# Altium schematic sheet Microbit Programming Interface.SchDoc
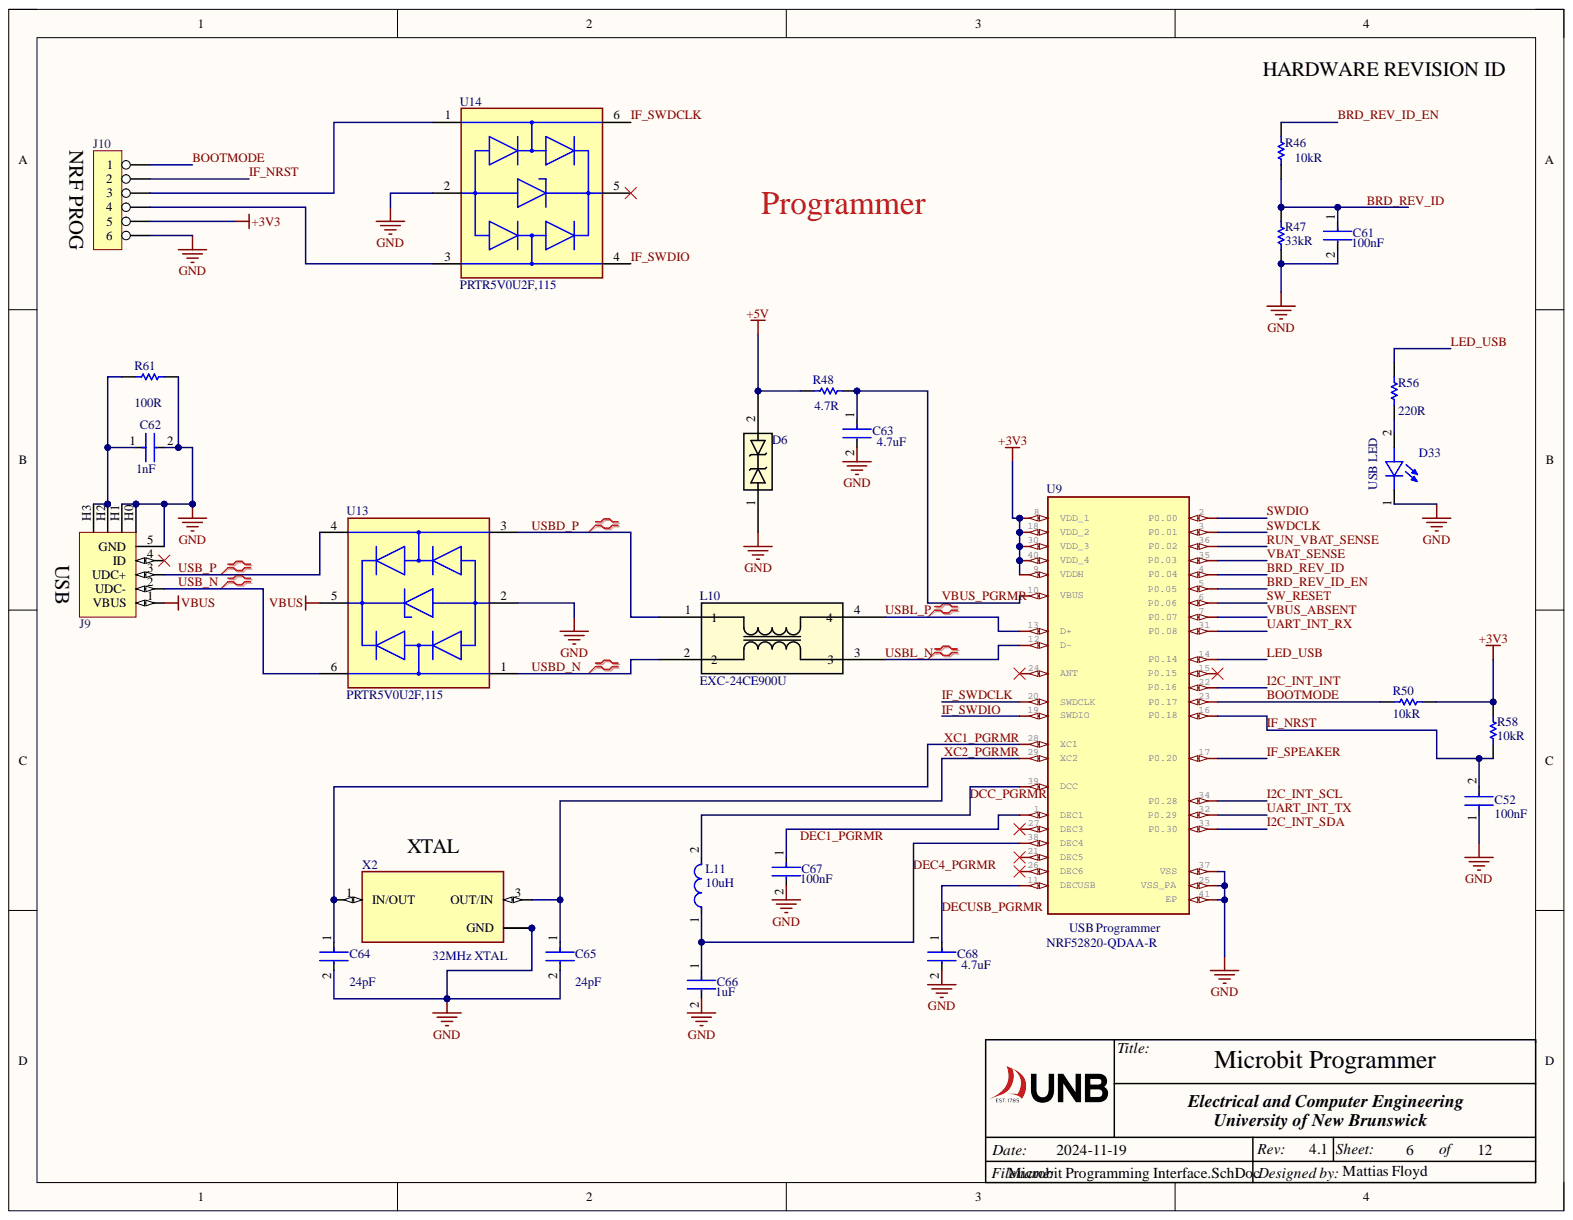
[diagram p0: Microbit Programming Interface.SchDoc]

## Parts
C52  Capacitance: 100nF  [0402 CAP]  (hidden comment: CAP GRM155R61H104KE14D)
C61  Capacitance: 100nF  [0402 CAP]  (hidden comment: CAP GRM155R61H104KE14D)
C62  Capacitance: 1nF  [0402 CAP]  (hidden comment: CAP CL05C102JB5NNNC)
C63  Capacitance: 4.7uF  [0402 CAP]  (hidden comment: CAP C1005X5R1A475K050BC)
C64  Capacitance: 24pF  [0402 CAP]  (hidden comment: CAP CC0402JRNPO9BN240)
C65  Capacitance: 24pF  [0402 CAP]  (hidden comment: CAP CC0402JRNPO9BN240)
C66  Capacitance: 1uF  [0402 CAP]  (hidden comment: CAP CC0402KRX5R5BB105)
C67  Capacitance: 100nF  [0402 CAP]  (hidden comment: CAP GRM155R61H104KE14D)
C68  Capacitance: 4.7uF  [0402 CAP]  (hidden comment: CAP C1005X5R1A475K050BC)
D6  DIODE D5V0H1B2LP-7B  [X1-DFN1006-2_DIO-L]  (value not drawn)
D33  USB LED  [0603 LED]
J9  CON_USB_MICRO_AB_MOLEX  [CON_USB_MICRO_AB_MOLEX]  (value not drawn)
J10  CON_HDR_6X1_0.1IN  [CON_HDR_6X1]  (value not drawn)
L10  EXC-24CE900U  [EXC24CE_PAN]
L11  10uH  [FP-LQM18FN_00-IPC_A]
R46  Resistance: 10kR  [0402 RES]  (hidden comment: RES MC00625W0402110K)
R47  Resistance: 33kR  [0402 RES]  (hidden comment: RES RC0402JR-0733KL)
R48  Resistance: 4.7R  [0402 RES]  (hidden comment: RES RC0402FR-074R7L)
R50  Resistance: 10kR  [0402 RES]  (hidden comment: RES MC00625W0402110K)
R56  Resistance: 220R  [0402 RES]  (hidden comment: RES ERJ-2RKF2200X)
R58  Resistance: 10kR  [0402 RES]  (hidden comment: RES MC00625W0402110K)
R61  RES RC0805JR-07100RL  [0805 RESISTOR]  (value not drawn)
U9  NRF52820-QDAA-R  [QFN40P500X500X90-41N]
U13  PRTR5V0U2F,115  [FP-SOT886-MFG]
U14  PRTR5V0U2F,115  [FP-SOT886-MFG]
X2  32MHz XTAL  [XTAL_ECS-320-16-33B-CKM-TR]

## Nets
+3V3: J10.5 R50.1 R58.1 U9.8(VDD_1) U9.9(VDDH) U9.18(VDD_2) U9.30(VDD_3) U9.40(VDD_4)
+5V: D6.2 R48.1
GND: C52.1 C61.2 C62.2 C63.2 C64.2 C65.2 C66.2 C67.2 C68.2 D6.1 D33.1 J9.5(GND) J9.H0 J9.H1 J10.6 R47.1 R61.2 U9.25(VSS_PA) U9.37(VSS) U9.41(EP) U13.2 U14.2 X2.2(GND) X2.4
VBUS: J9.1(VBUS) U13.5
BOOTMODE: J10.1 R50.2 U9.23(P0.17)
BRD_REV_ID: C61.1 R46.1 R47.2 U9.4(P0.04)
BRD_REV_ID_EN: R46.2 U9.5(P0.05)
DCC_PGRMR: L11.2 U9.39(DCC)
DEC4_PGRMR: C66.1 L11.1 U9.38(DEC4)
DECUSB_PGRMR: C68.1 U9.11(DECUSB)
I2C_INT_INT: U9.22(P0.16)
I2C_INT_SCL: U9.34(P0.28)
I2C_INT_SDA: U9.33(P0.30)
IF_NRST: C52.2 J10.2 R58.2 U9.16(P0.18)
IF_SPEAKER: U9.17(P0.20)
IF_SWDCLK: U9.20(SWDCLK) U14.6
IF_SWDIO: U9.19(SWDIO) U14.4
LED_USB: R56.2 U9.14(P0.14)
RUN_VBAT_SENSE: U9.36(P0.02)
SW_RESET: U9.6(P0.06)
SWDCLK: U9.3(P0.01)
SWDIO: U9.2(P0.00)
UART_INT_RX: U9.31(P0.08)
UART_INT_TX: U9.32(P0.29)
USB_N: J9.2(UDC-) U13.6
USB_P: J9.3(UDC+) U13.4
USBD_N: L10.2 U13.1
USBD_P: L10.1 U13.3
USBL_N: L10.3 U9.12(D-)
USBL_P: L10.4 U9.13(D+)
VBAT_SENSE: U9.35(P0.03)
VBUS_ABSENT: U9.7(P0.07)
VBUS_PGRMR: C63.1 R48.2 U9.10(VBUS)
XC1_PGRMR: C64.1 U9.28(XC1) X2.1(IN/OUT)
XC2_PGRMR: C65.1 U9.29(XC2) X2.3(OUT/IN)
NetC62_1: C62.1 J9.H2 J9.H3 R61.1
NetC67_1: C67.1 U9.1(DEC1)
NetD33_2: D33.2 R56.1
NetJ10_3: J10.3 U14.1
NetJ10_4: J10.4 U14.3
DEC1_PGRMR:

Not connected: J9 4(ID); U9 15(P0.15) 21(DEC5) 24(ANT) 26(DEC6) 27(DEC3); U14 5

## Library data of the parts
C52 C61 C67 = CAP GRM155R61H104KE14D: Ceramic Capacitor, Multilayer, Ceramic, 50V, 10% +Tol, 10% -Tol, X5R, 15% TC, 0.1uF, Surface Mount, 0402; Manufacturer: Murata; Multilayer: Yes; Capacitor Type: Ceramic; Category: Ceramic Capacitors; Component Kind: Standard; ComponentLink1Description: https://datasheet.ciiva.com:443/pdfs/VipMasterIC/IC/MURE/MURE-S-A0004362469/MURE-S-A0004364758-1.pdf?src-supplier=IHS+Markit; ComponentLink1URL: https://datasheet.ciiva.com:443/pdfs/VipMasterIC/IC/MURE/MURE-S-A0004362469/MURE-S-A0004364758-1.pdf?src-supplier=IHS+Markit; ComponentLink2Description: http://www.mouser.com/ds/2/281/c02e-2905.pdf?src-supplier=Mouser; ComponentLink2URL: http://www.mouser.com/ds/2/281/c02e-2905.pdf?src-supplier=Mouser; ComponentLink3Description: http://www.farnell.com/datasheets/2079150.pdf?src-supplier=Farnell; ComponentLink3URL: http://www.farnell.com/datasheets/2079150.pdf?src-supplier=Farnell; ComponentLink4Description: http://www.farnell.com/datasheets/2079150.pdf?src-supplier=Element14; ComponentLink4URL: http://www.farnell.com/datasheets/2079150.pdf?src-supplier=Element14; ComponentLink5Description: https://datasheet.octopart.com/GRM155R61H104KE14D-Murata-datasheet-66077066.pdf?src-supplier=Element14; ComponentLink5URL: https://datasheet.octopart.com/GRM155R61H104KE14D-Murata-datasheet-66077066.pdf?src-supplier=Element14; ComponentLink6Description: http://search.murata.co.jp/Ceramy/image/img/A01X/G101/ENG/GRM155R61H104KE14-01.pdf?src-supplier=Digikey; ComponentLink6URL: http://search.murata.co.jp/Ceramy/image/img/A01X/G101/ENG/GRM155R61H104KE14-01.pdf?src-supplier=Digikey; ComponentLink7Description: https://datasheet.octopart.com/GRM155R61H104KE14D-Murata-datasheet-22122223.pdf?src-supplier=Future+Electronics; ComponentLink7URL: https://datasheet.octopart.com/GRM155R61H104KE14D-Murata-datasheet-22122223.pdf?src-supplier=Future+Electronics; ComponentLink8Description: https://datasheet.octopart.com/GRM155R61H104KE14D-Murata-datasheet-149523029.pdf?src-supplier=Newark; ComponentLink8URL: https://datasheet.octopart.com/GRM155R61H104KE14D-Murata-datasheet-149523029.pdf?src-supplier=Newark; Dielectric Material: Ceramic; Height: 0.5mm; JESD-609 Code: e3; Length: 1mm; Manufacturer Part Number: GRM155R61H104KE14D; Max Operating Temperature: 85°C; Min Operating Temperature: -55°C; Mount: Surface Mount; Negative Tolerance: 10%; Number of Pins: 2; Package Shape: Rectangular; Package Style: SMTMeter; Packaging: Tape and Reel; Positive Tolerance: 10%; Pricing 1: 1=0.1, 10=0.031, 50=0.018, 100=0.0139, 500=0.01046, 1000=0.00821, 2500=0.00747, 5000=0.00687 (USD); Rated DC Voltage (URdc): 50V; Reach SVHC Compliant: Yes; RoHS: Yes; RoHS Compliant: Yes; Size Code: 0402; Stock 1: 1615754; Supplier 1: Digikey; Temperature Characteristics Code: X5R; Terminal Finish: Nickel; Termination: Wraparound; Width: 0.5mm; Library Name: bBoard for UNB Rev 3.SCHLIB
C62 = CAP CL05C102JB5NNNC: Ceramic Capacitor, Multilayer, Ceramic, 50V, 5% +Tol, 5% -Tol, C0G, -/+30ppm/Cel TC, 0.001uF, Surface Mount, 0402; Manufacturer: Samsung; Multilayer: Yes; Capacitor Type: Ceramic; Category: Ceramic Capacitors; Component Kind: Standard; ComponentLink1Description: https://datasheet.ciiva.com:443/pdfs/VipMasterIC/IC/SEMS/SEMS-S-A0008520254/SEMS-S-A0008520349-1.pdf?src-supplier=IHS+Markit; ComponentLink1URL: https://datasheet.ciiva.com:443/pdfs/VipMasterIC/IC/SEMS/SEMS-S-A0008520254/SEMS-S-A0008520349-1.pdf?src-supplier=IHS+Markit; ComponentLink2Description: https://datasheet.ciiva.com/25735/cl05c102jb5nnnc-25735686.pdf?src-supplier=Digikey; ComponentLink2URL: https://datasheet.ciiva.com/25735/cl05c102jb5nnnc-25735686.pdf?src-supplier=Digikey; ComponentLink3Description: https://datasheet.ciiva.com/29198/459210-da-01-en-vs-kondensator-0402-29198347.pdf?src-supplier=Conrad; ComponentLink3URL: https://datasheet.ciiva.com/29198/459210-da-01-en-vs-kondensator-0402-29198347.pdf?src-supplier=Conrad; ComponentLink4Description: https://datasheet.ciiva.com/26310/mlcc-catalogdownload2013-eng-26310343.pdf?src-supplier=Distrelec; ComponentLink4URL: https://datasheet.ciiva.com/26310/mlcc-catalogdownload2013-eng-26310343.pdf?src-supplier=Distrelec; Dielectric Material: Ceramic; Height: 0.55mm; JESD-609 Code: e3; Length: 1mm; Manufacturer Part Number: CL05C102JB5NNNC; Max Operating Temperature: 125°C; Min Operating Temperature: -55°C; Mount: Surface Mount; Negative Tolerance: 5%; Number of Pins: 2; Package Shape: Rectangular; Package Style: SMTMeter; Packaging: Tape and Reel; Positive Tolerance: 5%; Pricing 1: 1=0.11, 10=0.075, 50=0.0428, 100=0.0332, 500=0.02496, 1000=0.01961, 2500=0.01783, 5000=0.0164 (USD); Rated DC Voltage (URdc): 50V; Reach SVHC Compliant: Yes; RoHS: Yes; RoHS Compliant: Yes; Size Code: 0402; Stock 1: 129237; Supplier 1: Digikey; Supplier Part Number 1: 1276-1635-6-ND; Temperature Characteristics Code: C0G; Terminal Finish: Nickel; Termination: Wraparound; Width: 0.5mm; Library Name: bBoard for UNB Rev 4.SCHLIB
C63 C68 = CAP C1005X5R1A475K050BC: Ceramic Capacitor, Multilayer, Ceramic, 10V, 10% +Tol, 10% -Tol, X5R, 15% TC, 4.7uF, Surface Mount, 0402; Manufacturer: TDK; Multilayer: Yes; Capacitor Type: Ceramic; Category: Ceramic Capacitors; Component Kind: Standard; ComponentLink1Description: https://datasheet.ciiva.com:443/pdfs/VipMasterIC/IC/TDKC/TDKC-S-A0008796792/TDKC-S-A0008796792-1.pdf?src-supplier=IHS+Markit; ComponentLink1URL: https://datasheet.ciiva.com:443/pdfs/VipMasterIC/IC/TDKC/TDKC-S-A0008796792/TDKC-S-A0008796792-1.pdf?src-supplier=IHS+Markit; ComponentLink2Description: https://datasheet.ciiva.com/14895/tdkmlcccaprange-14895670.pdf?src-supplier=Digikey; ComponentLink2URL: https://datasheet.ciiva.com/14895/tdkmlcccaprange-14895670.pdf?src-supplier=Digikey; ComponentLink3Description: https://datasheet.ciiva.com/14895/tdkmlcccaprange-14895671.pdf?src-supplier=Digikey; ComponentLink3URL: https://datasheet.ciiva.com/14895/tdkmlcccaprange-14895671.pdf?src-supplier=Digikey; ComponentLink4Description: https://datasheet.ciiva.com/14895/tdkmlcccaprange-14895672.pdf?src-supplier=Digikey; ComponentLink4URL: https://datasheet.ciiva.com/14895/tdkmlcccaprange-14895672.pdf?src-supplier=Digikey; Dielectric Material: Ceramic; Height: 0.5mm; JESD-609 Code: e3; Length: 1mm; Manufacturer Part Number: C1005X5R1A475K050BC; Max Operating Temperature: 85°C; Min Operating Temperature: -55°C; Mount: Surface Mount; Negative Tolerance: 10%; Number of Pins: 2; Package Shape: Rectangular; Package Style: SMTMeter; Packaging: Tape and Reel; Positive Tolerance: 10%; Pricing 1: 1=0.28, 10=0.183, 50=0.1282, 100=0.1035, 500=0.07688, 1000=0.06727, 2500=0.06406, 5000=0.05926 (USD); Rated DC Voltage (URdc): 10V; Reach SVHC Compliant: Yes; RoHS: Yes; RoHS Compliant: Yes; Size Code: 0402; Stock 1: 1776806; Supplier 1: Digikey; Supplier Part Number 1: 445-13820-6-ND; Temperature Characteristics Code: X5R; Terminal Finish: Nickel; Termination: Wraparound; Width: 0.5mm; Library Name: bBoard for UNB Rev 4.SCHLIB
C64 C65 = CAP CC0402JRNPO9BN240: Ceramic Capacitor, Multilayer, Ceramic, 50V, 5% +Tol, 5% -Tol, C0G, -/+30ppm/Cel TC, 0.000024uF, Surface Mount, 0402; Manufacturer: Yageo; Multilayer: Yes; Capacitor Type: Ceramic; Category: Ceramic Capacitors; Component Kind: Standard; ComponentLink1Description: https://datasheet.ciiva.com:443/pdfs/VipMasterIC/IC/YAGO/YAGOS02297/YAGOS02297-1.pdf?src-supplier=IHS+Markit; ComponentLink1URL: https://datasheet.ciiva.com:443/pdfs/VipMasterIC/IC/YAGO/YAGOS02297/YAGOS02297-1.pdf?src-supplier=IHS+Markit; ComponentLink2Description: https://datasheet.ciiva.com/144/mlccorderingcode-144079.pdf?src-supplier=Digikey; ComponentLink2URL: https://datasheet.ciiva.com/144/mlccorderingcode-144079.pdf?src-supplier=Digikey; ComponentLink3Description: https://datasheet.ciiva.com/144/mlccorderingcode-144080.pdf?src-supplier=Digikey; ComponentLink3URL: https://datasheet.ciiva.com/144/mlccorderingcode-144080.pdf?src-supplier=Digikey; ComponentLink4Description: https://datasheet.ciiva.com/144/mlccorderingcode-144081.pdf?src-supplier=Digikey; ComponentLink4URL: https://datasheet.ciiva.com/144/mlccorderingcode-144081.pdf?src-supplier=Digikey; ComponentLink5Description: https://datasheet.ciiva.com/13386/upy-gp-np0-16v-to-50v-8-13386235.pdf?src-supplier=Mouser; ComponentLink5URL: https://datasheet.ciiva.com/13386/upy-gp-np0-16v-to-50v-8-13386235.pdf?src-supplier=Mouser; ComponentLink6Description: https://datasheet.ciiva.com/7656/1132289-7656617.pdf?src-supplier=Element14; ComponentLink6URL: https://datasheet.ciiva.com/7656/1132289-7656617.pdf?src-supplier=Element14; Dielectric Material: Ceramic; JESD-609 Code: e3; Manufacturer Part Number: CC0402JRNPO9BN240; Max Operating Temperature: 125°C; Min Operating Temperature: -55°C; Mount: Surface Mount; Negative Tolerance: 5%; Number of Pins: 2; Package Shape: Rectangular; Packaging: Tape and Reel; Positive Tolerance: 5%; Pricing 1: 1=0.1, 10=0.027, 50=0.0156, 100=0.0122, 500=0.00916, 1000=0.00719, 2500=0.00654, 5000=0.00602 (USD); Rated DC Voltage (URdc): 50V; Reach SVHC Compliant: Yes; RoHS: Yes; RoHS Compliant: Yes; Size Code: 0402; Stock 1: 195258; Supplier 1: Digikey; Supplier Part Number 1: 311-1348-6-ND; Temperature Characteristics Code: C0G; Terminal Finish: Nickel; Termination: Wraparound; Library Name: bBoard for UNB Rev 4.SCHLIB
C66 = CAP CC0402KRX5R5BB105: Ceramic Capacitor, Multilayer, Ceramic, 6.3V, 10% +Tol, 10% -Tol, X5R, 15% TC, 1uF, Surface Mount, 0402; Manufacturer: Yageo; Multilayer: Yes; Capacitor Type: Ceramic; Category: Ceramic Capacitors; Component Kind: Standard; ComponentLink1Description: https://datasheet.ciiva.com:443/pdfs/VipMasterIC/IC/YAGO/YAGO-S-A0006851646/YAGO-S-A0006851646-1.pdf?src-supplier=IHS+Markit; ComponentLink1URL: https://datasheet.ciiva.com:443/pdfs/VipMasterIC/IC/YAGO/YAGO-S-A0006851646/YAGO-S-A0006851646-1.pdf?src-supplier=IHS+Markit; ComponentLink2Description: https://datasheet.ciiva.com/5789/70765-5789520.pdf?src-supplier=Farnell; ComponentLink2URL: https://datasheet.ciiva.com/5789/70765-5789520.pdf?src-supplier=Farnell; ComponentLink3Description: https://datasheet.ciiva.com/176/mlccorderingcode-176609.pdf?src-supplier=Digikey; ComponentLink3URL: https://datasheet.ciiva.com/176/mlccorderingcode-176609.pdf?src-supplier=Digikey; ComponentLink4Description: https://datasheet.ciiva.com/176/mlccorderingcode-176610.pdf?src-supplier=Digikey; ComponentLink4URL: https://datasheet.ciiva.com/176/mlccorderingcode-176610.pdf?src-supplier=Digikey; ComponentLink5Description: https://datasheet.ciiva.com/176/mlccorderingcode-176611.pdf?src-supplier=Digikey; ComponentLink5URL: https://datasheet.ciiva.com/176/mlccorderingcode-176611.pdf?src-supplier=Digikey; ComponentLink6Description: https://datasheet.ciiva.com/11464/upy-gphc-x5r-4v-to-50v-12-11464863.pdf?src-supplier=Avnet; ComponentLink6URL: https://datasheet.ciiva.com/11464/upy-gphc-x5r-4v-to-50v-12-11464863.pdf?src-supplier=Avnet; ComponentLink7Description: https://datasheet.ciiva.com/8033/1525783-8033643.pdf?src-supplier=Element14; ComponentLink7URL: https://datasheet.ciiva.com/8033/1525783-8033643.pdf?src-supplier=Element14; ComponentLink8Description: https://datasheet.ciiva.com/8033/1525783-8033644.pdf?src-supplier=Element14; ComponentLink8URL: https://datasheet.ciiva.com/8033/1525783-8033644.pdf?src-supplier=Element14; ComponentLink9Description: https://datasheet.ciiva.com/8033/70765-8033646.pdf?src-supplier=Element14; ComponentLink9URL: https://datasheet.ciiva.com/8033/70765-8033646.pdf?src-supplier=Element14; ComponentLink10Description: https://datasheet.ciiva.com/28450/1359354-28450285.pdf?src-supplier=Element14; ComponentLink10URL: https://datasheet.ciiva.com/28450/1359354-28450285.pdf?src-supplier=Element14; Dielectric Material: Ceramic; Height: 0.5mm; JESD-609 Code: e3; Length: 1mm; Manufacturer Part Number: CC0402KRX5R5BB105; Max Operating Temperature: 85°C; Min Operating Temperature: -55°C; Mount: Surface Mount; Negative Tolerance: 10%; Number of Pins: 2; Package Shape: Rectangular; Package Style: SMTMeter; Packaging: Tape and Reel; Positive Tolerance: 10%; Pricing 1: 1=0.1, 10=0.054, 50=0.0308, 100=0.0239, 500=0.01796, 1000=0.01412, 2500=0.01283, 5000=0.01181 (USD); Rated DC Voltage (URdc): 6.3V; Reach SVHC Compliant: Yes; RoHS: Yes; RoHS Compliant: Yes; Size Code: 0402; Stock 1: 21426522; Supplier 1: Digikey; Supplier Part Number 1: 311-1438-1-ND; Temperature Characteristics Code: X5R; Terminal Finish: Nickel; Termination: Wraparound; Width: 0.5mm; Library Name: bBoard for UNB Rev 3.SCHLIB
D6 = DIODE D5V0H1B2LP-7B: Trans Voltage Suppressor Diode, 375W, 5.5V V(RWM), Bidirectional, 1 Element, Silicon; Manufacturer: Diodes; Max Breakdown Voltage: 9V; Max Power Dissipation: 250mW; Min Breakdown Voltage: 6V; Number of Elements: 1; Package Body Material: Plastic; Reference Standard: IEC-61000-4-5; Category: TVS Diodes; Component Kind: Standard; ComponentLink1Description: https://datasheet.ciiva.com:443/pdfs/VipMasterIC/IC/DIOD/DIOD-S-A0009689747/DIOD-S-A0009691837-1.pdf?src-supplier=IHS+Markit; ComponentLink1URL: https://datasheet.ciiva.com:443/pdfs/VipMasterIC/IC/DIOD/DIOD-S-A0009689747/DIOD-S-A0009691837-1.pdf?src-supplier=IHS+Markit; ComponentLink2Description: https://datasheet.octopart.com/D5V0H1B2LP-7B-Diodes-Inc.-datasheet-144967973.pdf?src-supplier=Farnell; ComponentLink2URL: https://datasheet.octopart.com/D5V0H1B2LP-7B-Diodes-Inc.-datasheet-144967973.pdf?src-supplier=Farnell; ComponentLink3Description: https://www.diodes.com/assets/Datasheets/D5V0H1B2LP.pdf?src-supplier=Digikey; ComponentLink3URL: https://www.diodes.com/assets/Datasheets/D5V0H1B2LP.pdf?src-supplier=Digikey; ComponentLink4Description: https://datasheet.octopart.com/D5V0H1B2LP-7B-Diodes-Inc.-datasheet-144967973.pdf?src-supplier=Newark; ComponentLink4URL: https://datasheet.octopart.com/D5V0H1B2LP-7B-Diodes-Inc.-datasheet-144967973.pdf?src-supplier=Newark; Diode Element Material: SILICON; Diode Type: TRANS VOLTAGE SUPPRESSOR; Manufacturer Part Number: D5V0H1B2LP-7B; Max Operating Temperature: 150°C; Min Operating Temperature: -65°C; Non-rep Peak Rev Power Dis-Max: 375W; Number of Pins: 2; Package Shape: Rectangular; Package Style: CHIP CARRIERMeter; Polarity: Bidirectional; Pricing 1: 1=0.24, 10=0.164, 100=0.08, 500=0.06672, 1000=0.04636, 2000=0.04018, 5000=0.03727 (USD); Reach SVHC Compliant: Yes; Rep Pk Reverse Voltage-Max: 5.5V; RoHS: Yes; RoHS Compliant: Yes; Stock 1: 206000; Supplier 1: Digikey; Supplier Part Number 1: D5V0H1B2LP-7BDICT-ND; Surface Mount: Yes; Technology: AVALANCHE; Terminal Form: No Lead; Terminal Position: BOTTOM; Library Name: bBoard for UNB Rev 4.SCHLIB
D33 = LTST-C193KSKT-5A: Single Color LED, Yellow, Water Clear, 1.15mm; Manufacturer: Vishay Lite-On; Color@wavelength: Orange; Forward Current-Max: 30mA; Forward Voltage-Max: 2.3V; Luminous Intensity-Min: 130cd; Luminous Intensity-Nom: 26.05mcd; Number of Functions: 1; Overall Height: 0.35mm; Reverse Voltage-Max: 5V; Shape: Rectangular; Viewing Angle: 130°; Category: LEDs; Color: Yellow; Component Kind: Standard; ComponentLink1Description: https://datasheet.ciiva.com:443/pdfs/VipMasterIC/IC/LITE/LITE-S-A0009748466/LITE-S-A0009831386-1.pdf?src-supplier=IHS+Markit; ComponentLink1URL: https://datasheet.ciiva.com:443/pdfs/VipMasterIC/IC/LITE/LITE-S-A0009748466/LITE-S-A0009831386-1.pdf?src-supplier=IHS+Markit; ComponentLink2Description: https://datasheet.ciiva.com/11775/0900766b80dc0553-11775611.pdf?src-supplier=Rs; ComponentLink2URL: https://datasheet.ciiva.com/11775/0900766b80dc0553-11775611.pdf?src-supplier=Rs; ComponentLink3Description: https://datasheet.ciiva.com/4688/lite-on-ltst-c193kskt-5a-187434-4688750.pdf?src-supplier=Mouser; ComponentLink3URL: https://datasheet.ciiva.com/4688/lite-on-ltst-c193kskt-5a-187434-4688750.pdf?src-supplier=Mouser; ComponentLink4Description: https://datasheet.ciiva.com/26890/getdatasheetpartid-683868-26890500.pdf?src-supplier=Verical; ComponentLink4URL: https://datasheet.ciiva.com/26890/getdatasheetpartid-683868-26890500.pdf?src-supplier=Verical; ComponentLink5Description: https://datasheet.ciiva.com/2110/s-110-ltst-c193kskt-5a-2110276.pdf?src-supplier=Digikey; ComponentLink5URL: https://datasheet.ciiva.com/2110/s-110-ltst-c193kskt-5a-2110276.pdf?src-supplier=Digikey; ComponentLink6Description: https://datasheet.ciiva.com/2110/s-110-ltst-c193kskt-5a-2110277.pdf?src-supplier=Digikey; ComponentLink6URL: https://datasheet.ciiva.com/2110/s-110-ltst-c193kskt-5a-2110277.pdf?src-supplier=Digikey; ComponentLink7Description: https://datasheet.ciiva.com/2110/s-110-ltst-c193kskt-5a-2110278.pdf?src-supplier=Digikey; ComponentLink7URL: https://datasheet.ciiva.com/2110/s-110-ltst-c193kskt-5a-2110278.pdf?src-supplier=Digikey; JESD-609 Code: e3; Lens Style: WATER CLEAR; Manufacturer Part Number: LTST-C193KSKT-5A; Max Operating Temperature: 85°C; Min Operating Temperature: -55°C; Mount: Surface Mount; Number of Pins: 2; Optoelectronic Device Type: SINGLE COLOR LED; Packaging: Tape and Reel; Pricing 1: 1=0.39, 10=0.211, 100=0.0981, 500=0.0906, 1000=0.07097, 2000=0.06946 (USD); Reach SVHC Compliant: Yes; RoHS: Yes; RoHS Compliant: Yes; Size: 1.15mm; Stock 1: 71849; Supplier 1: Digikey; Supplier Part Number 1: 160-1831-6-ND; Terminal Finish: Tin; Terminal Pitch: 1.5mm; Library Name: bBoard for UNB Rev 4.SCHLIB
J9 = CON_USB_MICRO_AB_MOLEX: MOLEX - 47589-0001 - USB Connector, Micro USB Type AB, USB 2.0, Receptacle, 5 Positions, Surface Mount, Right Angle; Connector Type: USB,Receptacle; Contact Resistance: 40mR; Current Rating: 1.8A; Gender: Female; Max Voltage Rating (AC): 100V; Number of Contacts: 5; Number of Ports: 1; Pitch: 0.65mm; USB Standard: USB 2.0; Voltage Rating: 30V; Category: USB Connectors; Color: Black; Component Kind: Standard; ComponentLink1Description: https://datasheet.ciiva.com/16148/0475890001-io-connectors-16148921.pdf?src-supplier=Onlinecomponents.com; ComponentLink1URL: https://datasheet.ciiva.com/16148/0475890001-io-connectors-16148921.pdf?src-supplier=Onlinecomponents.com; ComponentLink2Description: https://datasheet.ciiva.com/1098/1597783-1098478.pdf?src-supplier=Element14; ComponentLink2URL: https://datasheet.ciiva.com/1098/1597783-1098478.pdf?src-supplier=Element14; ComponentLink3Description: https://datasheet.ciiva.com/32371/0475890001-io-connectors-32371755.pdf?src-supplier=Onlinecomponents.com; ComponentLink3URL: https://datasheet.ciiva.com/32371/0475890001-io-connectors-32371755.pdf?src-supplier=Onlinecomponents.com; Contact Material: Copper Alloy,Copper; Contact Plating: Gold; Height: 0.25inch; Housing Material: Polymer; Length: 8.65mm; Manufacturer: Molex; Manufacturer Part Number: 47589-0001; Max Operating Temperature: 85°C; Min Operating Temperature: -20°C; Mount: Surface Mount,PCB; Number of Terminals: 5; Orientation: Right Angle; Packaging: Tape and Reel; Radiation Hardening: No; REACH SVHC: Unknown; RoHS: Yes; Stock 1: 6347; Supplier 1: Digi-Key; Supplier Part Number 1: WM17143CT-ND; Termination: Solder; Voltage Rating (AC): 30V; Weight: 0.01lb; Width: 0.25inch; Library Name: Arbury_CON.SchLib
J10 = CON_HDR_6X1_0.1IN: Female, 6 C, Straight, .100"CC; 3.20mm Tail, No Mt. 100u" Tin; PBT, Phos Bronze, 105 C; Manufacturer: Sullins; Manufacturer Part Number: PPTC061LFBN-RC; Connector Type: Header,Socket; Number of Positions: 6; Pitch: 0.1inch; Category: Connectors; Color: Black; Component Kind: Standard; ComponentLink1Description: https://media.digikey.com/pdf/Data%20Sheets/Sullins%20PDFs/Female_Headers.100_DS.pdf?src-supplier=Digi-Key; ComponentLink1URL: https://media.digikey.com/pdf/Data%20Sheets/Sullins%20PDFs/Female_Headers.100_DS.pdf?src-supplier=Digi-Key; ComponentLink2Description: http://www.sullinscorp.com/catalogs/78_Page80-81_HEADER_.100_2.54mm_FEMALE.pdf?src-supplier=Future+Electronics; ComponentLink2URL: http://www.sullinscorp.com/catalogs/78_Page80-81_HEADER_.100_2.54mm_FEMALE.pdf?src-supplier=Future+Electronics; Contact Material: Bronze; Contact Plating: Tin; Height: 8.5mm; Housing Material: Plastic; Lead Free: Lead Free; Length: 15.7mm; Mount: Through Hole; Number of Rows: 1; Package Quantity: 1000; Packaging: Bulk; RoHS: Yes; Stock 1: 37444; Supplier 1: Digi-Key; Supplier Part Number 1: S7004-ND; Termination: Solder; Width: 2.5mm; Library Name: Arbury_CON.SchLib
L10 = EXC-24CE900U: Data Line Filter, 2 Function(s), 5V, 0.16A; Manufacturer: Panasonic; Current Rating: 160mA; DC Resistance-Max: 1.75R; Number of Functions: 2; Output Impedance: 90R; Category: Common Mode Chokes; Component Kind: Standard; ComponentLink1Description: https://datasheet.ciiva.com:443/pdfs/VipMasterIC/IC/PANA/PANA-S-A0009068940/PANA-S-A0009068940-1.pdf?src-supplier=IHS+Markit; ComponentLink1URL: https://datasheet.ciiva.com:443/pdfs/VipMasterIC/IC/PANA/PANA-S-A0009068940/PANA-S-A0009068940-1.pdf?src-supplier=IHS+Markit; ComponentLink2Description: https://datasheet.ciiva.com/3859/aeh0000ce14-3859480.pdf?src-supplier=Arrow+Electronics; ComponentLink2URL: https://datasheet.ciiva.com/3859/aeh0000ce14-3859480.pdf?src-supplier=Arrow+Electronics; ComponentLink3Description: https://datasheet.ciiva.com/28631/1680436-28631300.pdf?src-supplier=Element14; ComponentLink3URL: https://datasheet.ciiva.com/28631/1680436-28631300.pdf?src-supplier=Element14; ComponentLink4Description: https://datasheet.ciiva.com/30069/aeh0000ce14-30069155.pdf?src-supplier=Onlinecomponents.com; ComponentLink4URL: https://datasheet.ciiva.com/30069/aeh0000ce14-30069155.pdf?src-supplier=Onlinecomponents.com; ComponentLink5Description: https://datasheet.ciiva.com/3068/aeh0000ce14-3068666.pdf?src-supplier=Avnet; ComponentLink5URL: https://datasheet.ciiva.com/3068/aeh0000ce14-3068666.pdf?src-supplier=Avnet; ComponentLink6Description: https://datasheet.ciiva.com/1007/aeh0000ce14-1007659.pdf?src-supplier=Digikey; ComponentLink6URL: https://datasheet.ciiva.com/1007/aeh0000ce14-1007659.pdf?src-supplier=Digikey; ComponentLink7Description: https://datasheet.ciiva.com/1007/aeh0000ce14-1007660.pdf?src-supplier=Digikey; ComponentLink7URL: https://datasheet.ciiva.com/1007/aeh0000ce14-1007660.pdf?src-supplier=Digikey; ComponentLink8Description: https://datasheet.ciiva.com/1007/aeh0000ce14-1007661.pdf?src-supplier=Digikey; ComponentLink8URL: https://datasheet.ciiva.com/1007/aeh0000ce14-1007661.pdf?src-supplier=Digikey; ComponentLink9Description: https://datasheet.ciiva.com/46388/1322267-46388349.pdf?src-supplier=Element14; ComponentLink9URL: https://datasheet.ciiva.com/46388/1322267-46388349.pdf?src-supplier=Element14; Filter Type: DATA LINE FILTER; Height: 0.5mm; Length: 1.25mm; Manufacturer Part Number: EXC-24CE900U; Max Operating Temperature: 85°C; Min Operating Temperature: -40°C; Mount: Surface Mount; Packaging: Tape and Reel; Pricing 1: 1=0.47, 10=0.34, 50=0.34, 100=0.265, 1000=0.213, 10000=0.192 (USD); Reach SVHC Compliant: Yes; RoHS: Yes; RoHS Compliant: Yes; Stock 1: 31808; Supplier 1: Mouser; Supplier Part Number 1: 667-EXC-24CE900U; Voltage Rating: 5V; Width: 1mm; Library Name: bBoard for UNB Rev 4.SCHLIB
L11 = LQM18FN100M00D: General Purpose Inductor, 10uH, 20%, 1 Element, Ferrite-Core, SMD, 0603; Manufacturer: Murata; Case/Size Code: 0603; DC Resistance (DCR): 1.17R; Inductor Application: RF INDUCTOR; Number of Functions: 1; Package Height: 0.8mm; Package Length: 1.6mm; Rated Current-Max: 50mA; Self Resonant Frequency: 30MHz; Shape/Size Description: RECTANGULAR PACKAGE; Shielding: Shielded; Test Frequency: 1MHz; Category: Fixed Inductors; Component Kind: Standard; ComponentLink1Description: https://psearch.en.murata.com:443/inductor/product/LQM18FN100M00_.pdf?src-supplier=IHS+Markit; ComponentLink1URL: https://psearch.en.murata.com:443/inductor/product/LQM18FN100M00_.pdf?src-supplier=IHS+Markit; ComponentLink2Description: https://datasheet.ciiva.com/3865/286lqm18fn100m0023-3865365.pdf?src-supplier=Arrow+Electronics; ComponentLink2URL: https://datasheet.ciiva.com/3865/286lqm18fn100m0023-3865365.pdf?src-supplier=Arrow+Electronics; ComponentLink3Description: https://datasheet.ciiva.com/11799/0900766b80f15512-11799471.pdf?src-supplier=Rs; ComponentLink3URL: https://datasheet.ciiva.com/11799/0900766b80f15512-11799471.pdf?src-supplier=Rs; ComponentLink4Description: https://datasheet.ciiva.com/1039/l0075s0097lqm18f-1039417.pdf?src-supplier=Digikey; ComponentLink4URL: https://datasheet.ciiva.com/1039/l0075s0097lqm18f-1039417.pdf?src-supplier=Digikey; ComponentLink5Description: https://datasheet.ciiva.com/1039/l0075s0097lqm18f-1039418.pdf?src-supplier=Digikey; ComponentLink5URL: https://datasheet.ciiva.com/1039/l0075s0097lqm18f-1039418.pdf?src-supplier=Digikey; ComponentLink6Description: https://datasheet.ciiva.com/1039/l0075s0097lqm18f-1039419.pdf?src-supplier=Digikey; ComponentLink6URL: https://datasheet.ciiva.com/1039/l0075s0097lqm18f-1039419.pdf?src-supplier=Digikey; ComponentLink7Description: https://datasheet.ciiva.com/8510/l0075s0097lqm18f-8510087.pdf?src-supplier=Avnet; ComponentLink7URL: https://datasheet.ciiva.com/8510/l0075s0097lqm18f-8510087.pdf?src-supplier=Avnet; ComponentLink8Description: https://datasheet.ciiva.com/8510/l0075s0097lqm18f-8510328.pdf?src-supplier=Avnet; ComponentLink8URL: https://datasheet.ciiva.com/8510/l0075s0097lqm18f-8510328.pdf?src-supplier=Avnet; Construction: Multilayer Chip; Core Material: Ferrite; Inductance-Nom (L): 10uH; Inductor Type: GENERAL PURPOSE INDUCTOR; JESD-609 Code: e3; Manufacturer Part Number: LQM18FN100M00D; Max Operating Temperature: 125°C; Min Operating Temperature: -55°C; Number of Pins: 2; Package Style: SMTMeter; Package Width: 0.8mm; Packaging: Tape and Reel; Pricing 1: 1=0.18, 10=0.149, 25=0.1372, 50=0.1212, 100=0.106, 250=0.10096, 500=0.09488, 1000=0.07268 (USD); Reach SVHC Compliant: Yes; RoHS: Yes; RoHS Compliant: Yes; Stock 1: 351983; Supplier 1: Digikey; Supplier Part Number 1: 490-4025-1-ND; Surface Mount: Yes; Terminal Finish: Tin; Terminal Placement: Dual Ended; Termination: Wraparound; Tolerance: 20%; Library Name: bBoard for UNB Rev 4.SCHLIB
R46 R50 R58 = RES MC00625W0402110K: Fixed Resistor, Metal Glaze/thick Film, 0.0625W, 10000ohm, 50V, 1% +/-Tol, 100ppm/Cel, Surface Mount, 0402; Manufacturer: Multicomp; Rated Power Dissipation (P): 63mW; Rated Temperature: 70°C; Temperature Coefficient: 100ppm/°C; Category: Chip SMD Resistors; Component Kind: Standard; ComponentLink1Description: https://datasheet.ciiva.com:443/pdfs/VipMasterIC/IC/SCMP/SCMPS00098/SCMPS00098-1.pdf?src-supplier=IHS+Markit; ComponentLink1URL: https://datasheet.ciiva.com:443/pdfs/VipMasterIC/IC/SCMP/SCMPS00098/SCMPS00098-1.pdf?src-supplier=IHS+Markit; ComponentLink2Description: https://datasheet.ciiva.com/28638/1729045-28638923.pdf?src-supplier=Element14; ComponentLink2URL: https://datasheet.ciiva.com/28638/1729045-28638923.pdf?src-supplier=Element14; Manufacturer Part Number: MC00625W0402110K; Max Operating Temperature: 155°C; Min Operating Temperature: -55°C; Mount: Surface Mount; Number of Pins: 2; Package Shape: Rectangular; Packaging: Tape and Reel; Pricing 1: 10000=0.006, 20000=0.005, 50000=0.004, 100000=0.002 (USD); Reach SVHC Compliant: Yes; Resistor Type: FIXED RESISTOR; RoHS: Yes; RoHS Compliant: Yes; Size Code: 0402; Stock 1: 700000; Supplier 1: Newark; Supplier Part Number 1: 07W4403; Technology: METAL GLAZE/THICK FILM; Termination: Wraparound; Tolerance: 1%; Working Voltage: 50V; Library Name: bBoard for UNB Rev 3.SCHLIB
R47 = RES RC0402JR-0733KL: Fixed Resistor, Metal Glaze/thick Film, 0.0625W, 33000ohm, 50V, 5% +/-Tol, 100ppm/Cel, Surface Mount, 0402; Manufacturer: Yageo; Additional Feature: LASER TRIMMABLE; Package Height: 0.35mm; Package Length: 1mm; Package Width: 0.5mm; Rated Power Dissipation (P): 62.5mW; Rated Temperature: 70°C; Temperature Coefficient: 100ppm/°C; Category: Chip SMD Resistors; Component Kind: Standard; ComponentLink1Description: https://datasheet.ciiva.com:443/pdfs/VipMasterIC/IC/YAGO/YAGO-S-A0008145938/YAGO-S-A0008145938-1.pdf?src-supplier=IHS+Markit; ComponentLink1URL: https://datasheet.ciiva.com:443/pdfs/VipMasterIC/IC/YAGO/YAGO-S-A0008145938/YAGO-S-A0008145938-1.pdf?src-supplier=IHS+Markit; ComponentLink2Description: https://datasheet.ciiva.com/7850/1018594-7850198.pdf?src-supplier=Element14; ComponentLink2URL: https://datasheet.ciiva.com/7850/1018594-7850198.pdf?src-supplier=Element14; ComponentLink3Description: https://datasheet.ciiva.com/7850/1018594-7850199.pdf?src-supplier=Element14; ComponentLink3URL: https://datasheet.ciiva.com/7850/1018594-7850199.pdf?src-supplier=Element14; ComponentLink4Description: https://datasheet.ciiva.com/7983/1018594-7983578.pdf?src-supplier=Element14; ComponentLink4URL: https://datasheet.ciiva.com/7983/1018594-7983578.pdf?src-supplier=Element14; ComponentLink5Description: https://datasheet.ciiva.com/5558/pyu-rc0402-51-rohs-l-6-r-5558154.pdf?src-supplier=Mouser; ComponentLink5URL: https://datasheet.ciiva.com/5558/pyu-rc0402-51-rohs-l-6-r-5558154.pdf?src-supplier=Mouser; ComponentLink6Description: https://datasheet.ciiva.com/16740/pyu-rc0402-51-rohs-l-6-r-16740743.pdf?src-supplier=Future+Electronics; ComponentLink6URL: https://datasheet.ciiva.com/16740/pyu-rc0402-51-rohs-l-6-r-16740743.pdf?src-supplier=Future+Electronics; Construction: Chip; JESD-609 Code: e3; Manufacturer Part Number: RC0402JR-0733KL; Max Operating Temperature: 155°C; Min Operating Temperature: -55°C; Mount: Surface Mount; Number of Pins: 2; Package Shape: Rectangular; Package Style: SMTMeter; Packaging: Tape and Reel; Pricing 1: 1=0.1, 10=0.018, 50=0.0102, 100=0.0083, 500=0.00528, 1000=0.00444, 5000=0.00307 (USD); Reach SVHC Compliant: Yes; Resistor Type: FIXED RESISTOR; RoHS: Yes; RoHS Compliant: Yes; Size Code: 0402; Stock 1: 166093; Supplier 1: Digikey; Supplier Part Number 1: 311-33KJRCT-ND; Technology: METAL GLAZE/THICK FILM; Terminal Finish: Nickel; Termination: Wraparound; Tolerance: 5%; Working Voltage: 50V; Library Name: bBoard for UNB Rev 4.SCHLIB
R48 = RES RC0402FR-074R7L: Fixed Resistor, Metal Glaze/thick Film, 0.0625W, 4.7ohm, 50V, 1% +/-Tol, 200ppm/Cel, Surface Mount, 0402; Manufacturer: Yageo; Additional Feature: LASER TRIMMABLE; Package Height: 0.35mm; Package Length: 1mm; Package Width: 0.5mm; Rated Power Dissipation (P): 62.5mW; Rated Temperature: 70°C; Temperature Coefficient: 200ppm/°C; Category: Chip SMD Resistors; Component Kind: Standard; ComponentLink1Description: https://datasheet.ciiva.com:443/pdfs/VipMasterIC/IC/YAGO/YAGO-S-A0008145938/YAGO-S-A0008145938-1.pdf?src-supplier=IHS+Markit; ComponentLink1URL: https://datasheet.ciiva.com:443/pdfs/VipMasterIC/IC/YAGO/YAGO-S-A0008145938/YAGO-S-A0008145938-1.pdf?src-supplier=IHS+Markit; ComponentLink2Description: https://datasheet.ciiva.com/12577/pyu-rc0402-51-rohs-l-6-r-12577562.pdf?src-supplier=Mouser; ComponentLink2URL: https://datasheet.ciiva.com/12577/pyu-rc0402-51-rohs-l-6-r-12577562.pdf?src-supplier=Mouser; ComponentLink3Description: https://datasheet.ciiva.com/7850/1018594-7850030.pdf?src-supplier=Element14; ComponentLink3URL: https://datasheet.ciiva.com/7850/1018594-7850030.pdf?src-supplier=Element14; ComponentLink4Description: https://datasheet.ciiva.com/7850/1018594-7850031.pdf?src-supplier=Element14; ComponentLink4URL: https://datasheet.ciiva.com/7850/1018594-7850031.pdf?src-supplier=Element14; ComponentLink5Description: https://datasheet.ciiva.com/17419/pyu-rc0402-51-rohs-l-6-r-17419593.pdf?src-supplier=Future+Electronics; ComponentLink5URL: https://datasheet.ciiva.com/17419/pyu-rc0402-51-rohs-l-6-r-17419593.pdf?src-supplier=Future+Electronics; Construction: Chip; JESD-609 Code: e3; Manufacturer Part Number: RC0402FR-074R7L; Max Operating Temperature: 155°C; Min Operating Temperature: -55°C; Mount: Surface Mount; Number of Pins: 2; Package Shape: Rectangular; Package Style: SMTMeter; Packaging: Tape and Reel; Pricing 1: 1=0.1, 10=0.021, 50=0.013, 100=0.0107, 500=0.00714, 1000=0.0061, 5000=0.00438 (USD); Reach SVHC Compliant: Yes; Resistor Type: FIXED RESISTOR; RoHS: Yes; RoHS Compliant: Yes; Size Code: 0402; Stock 1: 149468; Supplier 1: Digikey; Supplier Part Number 1: 311-4.70LRCT-ND; Technology: METAL GLAZE/THICK FILM; Terminal Finish: Nickel; Termination: Wraparound; Tolerance: 1%; Working Voltage: 50V; Library Name: bBoard for UNB Rev 4.SCHLIB
R56 = RES ERJ-2RKF2200X: Fixed Resistor, Metal Glaze/thick Film, 0.1W, 220ohm, 50V, 1% +/-Tol, 100ppm/Cel, Surface Mount, 0402; Manufacturer: Panasonic; Package Height: 0.35mm; Package Length: 1mm; Package Width: 0.5mm; Rated Power Dissipation (P): 100mW; Rated Temperature: 70°C; Reference Standard: AEC-Q200; Temperature Coefficient: 100ppm/°C; Category: Chip SMD Resistors; Component Kind: Standard; ComponentLink1Description: https://datasheet.ciiva.com:443/pdfs/VipMasterIC/IC/PANA/PANA-S-A0009889626/PANA-S-A0009889626-1.pdf?src-supplier=IHS+Markit; ComponentLink1URL: https://datasheet.ciiva.com:443/pdfs/VipMasterIC/IC/PANA/PANA-S-A0009889626/PANA-S-A0009889626-1.pdf?src-supplier=IHS+Markit; ComponentLink2Description: https://datasheet.ciiva.com/11821/0900766b80f80784-11821255.pdf?src-supplier=Rs; ComponentLink2URL: https://datasheet.ciiva.com/11821/0900766b80f80784-11821255.pdf?src-supplier=Rs; ComponentLink3Description: https://datasheet.ciiva.com/16762/70260748-16762119.pdf?src-supplier=Allied+Electronics; ComponentLink3URL: https://datasheet.ciiva.com/16762/70260748-16762119.pdf?src-supplier=Allied+Electronics; ComponentLink4Description: https://datasheet.ciiva.com/16784/70260748-16784822.pdf?src-supplier=Allied+Electronics; ComponentLink4URL: https://datasheet.ciiva.com/16784/70260748-16784822.pdf?src-supplier=Allied+Electronics; ComponentLink5Description: https://datasheet.ciiva.com/7886/aoa0000ce2-7886716.pdf?src-supplier=Element14; ComponentLink5URL: https://datasheet.ciiva.com/7886/aoa0000ce2-7886716.pdf?src-supplier=Element14; ComponentLink6Description: https://datasheet.ciiva.com/46372/1678782-46372860.pdf?src-supplier=Element14; ComponentLink6URL: https://datasheet.ciiva.com/46372/1678782-46372860.pdf?src-supplier=Element14; ComponentLink7Description: https://datasheet.ciiva.com/46372/1678782-46372861.pdf?src-supplier=Element14; ComponentLink7URL: https://datasheet.ciiva.com/46372/1678782-46372861.pdf?src-supplier=Element14; ComponentLink8Description: https://datasheet.ciiva.com/9184/aoa0000ce2-9184270.pdf?src-supplier=Avnet; ComponentLink8URL: https://datasheet.ciiva.com/9184/aoa0000ce2-9184270.pdf?src-supplier=Avnet; Construction: Rectangular; JESD-609 Code: e3; Manufacturer Part Number: ERJ-2RKF2200X; Max Operating Temperature: 155°C; Min Operating Temperature: -55°C; Mount: Surface Mount; Number of Pins: 2; Package Shape: Rectangular; Package Style: SMTMeter; Packaging: Tape and Reel; Pricing 1: 1=0.1, 10=0.024, 50=0.0152, 100=0.0127, 500=0.00866, 1000=0.00746, 5000=0.00542 (USD); Reach SVHC Compliant: Yes; Resistor Type: FIXED RESISTOR; RoHS: Yes; RoHS Compliant: Yes; Size Code: 0402; Stock 1: 139215; Supplier 1: Digikey; Supplier Part Number 1: P220LCT-ND; Technology: METAL GLAZE/THICK FILM; Terminal Finish: Nickel; Termination: Wraparound; Tolerance: 1%; Working Voltage: 50V; Library Name: bBoard for UNB Rev 4.SCHLIB
R61 = RES RC0805JR-07100RL: Fixed Resistor, Metal Glaze/thick Film, 0.125W, 100ohm, 150V, 5% +/-Tol, 100ppm/Cel, Surface Mount, 0805; Manufacturer: Yageo; Additional Feature: LASER TRIMMABLE; Package Height: 0.5mm; Package Length: 2mm; Package Width: 1.25mm; Rated Power Dissipation (P): 125mW; Rated Temperature: 70°C; Resistance: 100R; Temperature Coefficient: 100ppm/°C; Category: Chip SMD Resistors; Component Kind: Standard; ComponentLink1Description: https://datasheet.ciiva.com:443/pdfs/VipMasterIC/IC/YAGO/YAGO-S-A0008145938/YAGO-S-A0008145938-1.pdf?src-supplier=IHS+Markit; ComponentLink1URL: https://datasheet.ciiva.com:443/pdfs/VipMasterIC/IC/YAGO/YAGO-S-A0008145938/YAGO-S-A0008145938-1.pdf?src-supplier=IHS+Markit; ComponentLink2Description: https://datasheet.ciiva.com/7941/442941-7941868.pdf?src-supplier=Element14; ComponentLink2URL: https://datasheet.ciiva.com/7941/442941-7941868.pdf?src-supplier=Element14; ComponentLink3Description: https://datasheet.ciiva.com/7941/442941-7941869.pdf?src-supplier=Element14; ComponentLink3URL: https://datasheet.ciiva.com/7941/442941-7941869.pdf?src-supplier=Element14; ComponentLink4Description: https://datasheet.ciiva.com/46395/444164-46395111.pdf?src-supplier=Element14; ComponentLink4URL: https://datasheet.ciiva.com/46395/444164-46395111.pdf?src-supplier=Element14; ComponentLink5Description: https://datasheet.ciiva.com/12667/rc0805-12667419.pdf?src-supplier=Mouser; ComponentLink5URL: https://datasheet.ciiva.com/12667/rc0805-12667419.pdf?src-supplier=Mouser; ComponentLink6Description: https://datasheet.ciiva.com/16962/rc0805-16962143.pdf?src-supplier=Future+Electronics; ComponentLink6URL: https://datasheet.ciiva.com/16962/rc0805-16962143.pdf?src-supplier=Future+Electronics; Construction: Chip; JESD-609 Code: e3; Manufacturer Part Number: RC0805JR-07100RL; Max Operating Temperature: 155°C; Min Operating Temperature: -55°C; Mount: Surface Mount; Number of Pins: 2; Package Shape: Rectangular; Package Style: SMTMeter; Packaging: Tape and Reel; Pricing 1: 1=0.1, 10=0.023, 50=0.0144, 100=0.012, 500=0.00818, 1000=0.00706 (USD); Reach SVHC Compliant: Yes; Resistor Type: FIXED RESISTOR; RoHS: Yes; RoHS Compliant: Yes; Size Code: 0805; Stock 1: 402901; Supplier 1: Digikey; Supplier Part Number 1: 311-100ARCT-ND; Technology: METAL GLAZE/THICK FILM; Terminal Finish: Nickel; Termination: Wraparound; Tolerance: 5%; Working Voltage: 150V; Library Name: bBoard for UNB Rev 4.SCHLIB
U9 = NRF52820-QDAA-R: Rf Transceiver, Bluetooth, 2.5Ghz Rohs Compliant: Yes; Manufacturer: Nordic Semiconductor; Category: RF Receivers, Transceivers; Component Kind: Standard; ComponentLink1Description: https://infocenter.nordicsemi.com/pdf/nRF52820_OPS_v0.7.pdf?src-supplier=Digikey; ComponentLink1URL: https://infocenter.nordicsemi.com/pdf/nRF52820_OPS_v0.7.pdf?src-supplier=Digikey; Manufacturer Part Number: NRF52820-QDAA-R; Pricing 1: 1=2.82, 100=2.41, 500=2.09, 1000=1.91001 (USD); RoHS: No; Stock 1: 39890; Supplier 1: Digikey; Supplier Part Number 1: 4823-NRF52820-QDAA-RDKR-ND; Library Name: bBoard for UNB Rev 4.SCHLIB
U13 U14 = PRTR5V0U2F,115: Trans Voltage Suppressor Diode, 5.5V V(RWM), Unidirectional, 1 Element, Silicon, MO-252; Manufacturer: Nexperia; Max Breakdown Voltage: 9V; Min Breakdown Voltage: 6V; Number of Elements: 1; Package Body Material: Plastic; Time @ Peak Reflow Temperature-Max (s): 30s; Category: ESD and Circuit Protection ICs; Component Kind: Standard; ComponentLink1Description: https://datasheet.ciiva.com:443/pdfs/VipMasterIC/IC/NEXP/NEXP-S-A0003376312/NEXP-S-A0003376312-1.pdf?src-supplier=IHS+Markit; ComponentLink1URL: https://datasheet.ciiva.com:443/pdfs/VipMasterIC/IC/NEXP/NEXP-S-A0003376312/NEXP-S-A0003376312-1.pdf?src-supplier=IHS+Markit; ComponentLink2Description: http://www.farnell.com/datasheets/1712879.pdf?src-supplier=Farnell; ComponentLink2URL: http://www.farnell.com/datasheets/1712879.pdf?src-supplier=Farnell; ComponentLink3Description: https://assets.nexperia.com/documents/data-sheet/PRTR5V0U2F_PRTR5V0U2K.pdf?src-supplier=Digikey; ComponentLink3URL: https://assets.nexperia.com/documents/data-sheet/PRTR5V0U2F_PRTR5V0U2K.pdf?src-supplier=Digikey; ComponentLink4Description: https://datasheet.octopart.com/PRTR5V0U2F%2C115-Nexperia-datasheet-8330238.pdf?src-supplier=Newark; ComponentLink4URL: https://datasheet.octopart.com/PRTR5V0U2F%2C115-Nexperia-datasheet-8330238.pdf?src-supplier=Newark; ComponentLink5Description: https://datasheet.octopart.com/PRTR5V0U2F%2C115-Nexperia-datasheet-137756209.pdf?src-supplier=LKR; ComponentLink5URL: https://datasheet.octopart.com/PRTR5V0U2F%2C115-Nexperia-datasheet-137756209.pdf?src-supplier=LKR; Diode Element Material: SILICON; Diode Type: TRANS VOLTAGE SUPPRESSOR; JESD-609 Code: e3; Manufacturer Part Number: PRTR5V0U2F,115; Moisture Sensitivity Level: 1; Number of Pins: 6; Package Shape: Rectangular; Package Style: CHIP CARRIERMeter; Peak Reflow Temperature (Cel): 260°C; Polarity: Unidirectional; Pricing 1: 1=0.29, 10=0.244, 100=0.1698, 500=0.1326, 1000=0.115 (USD); Reach SVHC Compliant: Yes; Rep Pk Reverse Voltage-Max: 5.5V; RoHS: Yes; RoHS Compliant: Yes; Stock 1: 171505; Supplier 1: Digikey; Supplier Part Number 1: 1727-4161-1-ND; Surface Mount: Yes; Technology: ZENER; Terminal Finish: Tin; Terminal Form: No Lead; Terminal Position: BOTTOM; Library Name: bBoard for UNB Rev 4.SCHLIB
X2 = XTAL ECS-320-16-33B-CKM-TR: Parallel - Fundamental Quartz Crystal, 32MHz Nom; Manufacturer: ECS International; Component Kind: Standard; ComponentLink1Description: https://datasheet.ciiva.com:443/pdfs/VipMasterIC/IC/ECSI/ECSI-S-A0004428075/ECSI-S-A0004428075-1.pdf?src-supplier=IHS+Markit; ComponentLink1URL: https://datasheet.ciiva.com:443/pdfs/VipMasterIC/IC/ECSI/ECSI-S-A0004428075/ECSI-S-A0004428075-1.pdf?src-supplier=IHS+Markit; ComponentLink2Description: https://ecsxtal.com/store/pdf/ECS-33B.pdf?src-supplier=Digikey; ComponentLink2URL: https://ecsxtal.com/store/pdf/ECS-33B.pdf?src-supplier=Digikey; Crystal/Resonator Type: PARALLEL - FUNDAMENTAL; Manufacturer Part Number: ECS-320-16-33B-CKM-TR; Pricing 1: 1=0.61, 10=0.505, 50=0.4544, 100=0.404, 500=0.3838 (USD); Reach SVHC Compliant: Yes; RoHS: Yes; RoHS Compliant: Yes; Stock 1: 1933; Supplier 1: Digikey; Supplier Part Number 1: XC2531CT-ND; Library Name: bBoard for UNB Rev 4.SCHLIB
